# Altium schematic sheet ip2368_out_board.SchDoc
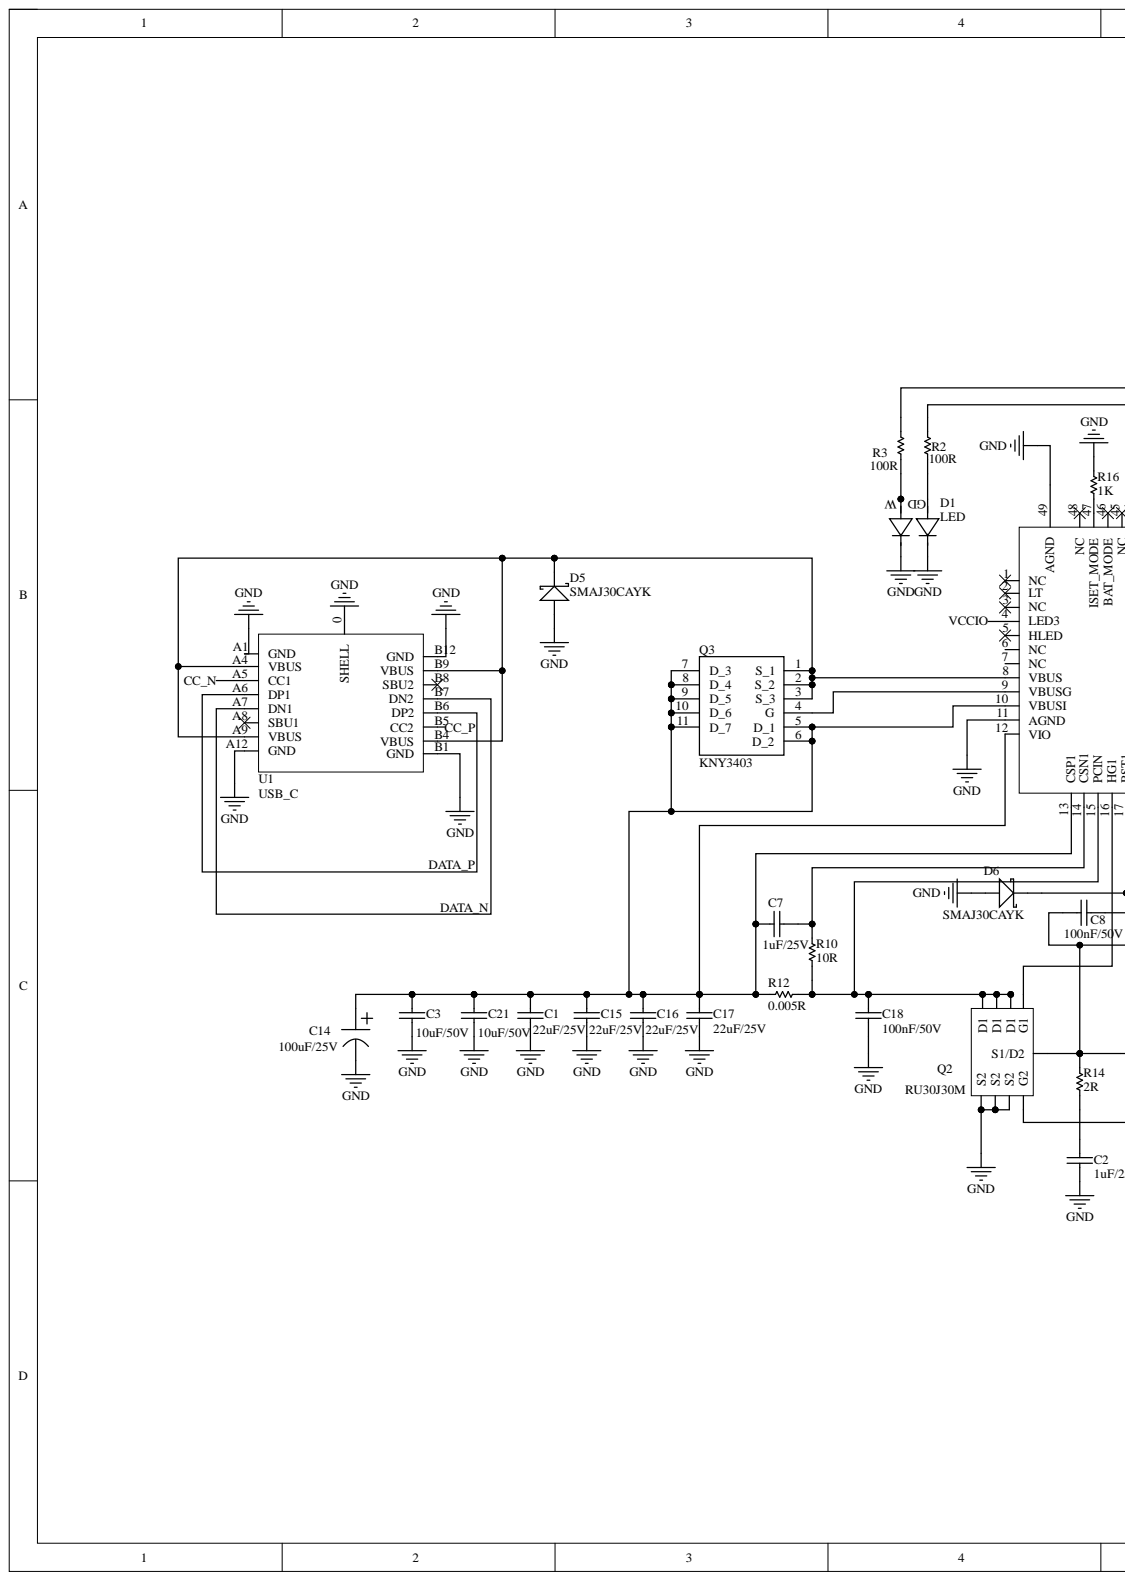
[diagram p0: ip2368_out_board.SchDoc, left]
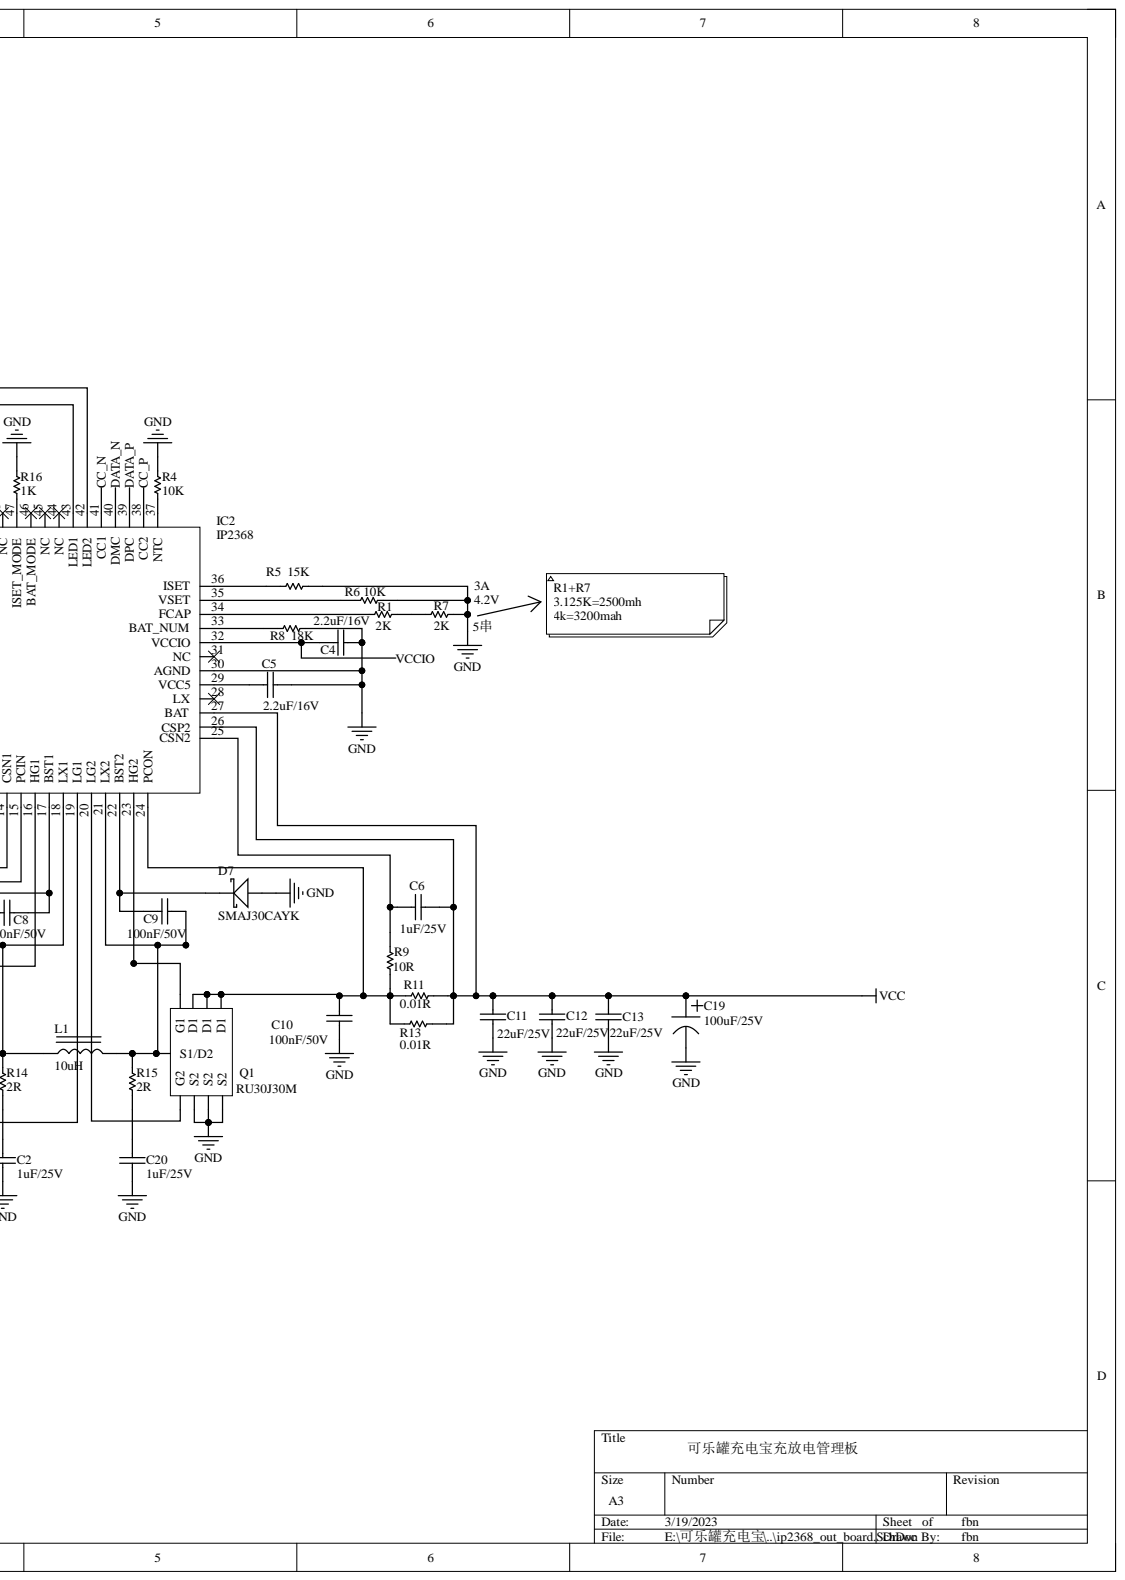
[diagram p1: ip2368_out_board.SchDoc, right]

## Parts
C1  22uF/25V  [1206C]
C2  1uF/25V  [0402C]
C3  10uF/50V  [0805C]
C4  2.2uF/16V  [0402C]
C5  2.2uF/16V  [0402C]
C6  1uF/25V  [0402C]
C7  1uF/25V  [0402C]
C8  100nF/50V  [0402C]
C9  100nF/50V  [0402C]
C10  100nF/50V  [0402C]
C11  22uF/25V  [1206C]
C12  22uF/25V  [1206C]
C13  22uF/25V  [1206C]
C14  100uF/25V  [SMD_2x5x8]
C15  22uF/25V  [1206C]
C16  22uF/25V  [1206C]
C17  22uF/25V  [1206C]
C18  100nF/50V  [0402C]
C19  100uF/25V  [SMD_2x5x8]
C20  1uF/25V  [0402C]
C21  10uF/50V  [0805C]
D1  LED  [SMD2835]
D5  SMAJ30CAYK  [SOD-123]
D6  SMAJ30CAYK  [SOD-123]
D7  SMAJ30CAYK  [SOD-123]
IC2  IP2368  [QFN50P700X700X90-49N-D]
L1  10uH  [SMD_12x12x6]
Q1  RU30J30M  [PDFN5x6]
Q2  RU30J30M  [PDFN5x6]
Q3  KNY3403  [DFN5x6]
R1  2K  [0402R]
R2  100R  [0402R]
R3  100R  [0402R]
R4  10K  [0402R]
R5  15K  [0402R]
R6  10K  [0402R]
R7  2K  [0402R]
R8  18K  [0402R]
R9  10R  [0402R]
R10  10R  [0402R]
R11  0.01R  [1206R]
R12  0.005R  [2512R]
R13  0.01R  [1206R]
R14  2R  [0402R]
R15  2R  [0402R]
R16  1K  [0402R]
U1  USB_C  [USB-C 立贴]

## Nets
GND: C1.1 C2.2 C3.1 C4.1 C5.1 C10.1 C11.1 C12.1 C13.1 C14.1 C15.1 C16.1 C17.1 C18.1 C19.1 C20.2 C21.1 D1.1 D1.3 D5.A D6.A D7.A IC2.11(AGND) IC2.30(AGND) IC2.49(AGND) Q1.5(S2) Q1.6(S2) Q1.7(S2) Q2.5(S2) Q2.6(S2) Q2.7(S2) R4.2 R5.2 R6.2 R7.2 R8.2 R16.2 U1.0(SHELL) U1.A1(GND) U1.A12(GND) U1.B1(GND) U1.B12(GND)
VCC: C6.1 C11.2 C12.2 C13.2 C19.0 IC2.26(CSP2) IC2.27(BAT) R11.2 R13.2
CC_N: IC2.41(CC1) U1.A5(CC1)
CC_P: IC2.38(CC2) U1.B5(CC2)
DATA_N: IC2.40(DMC) U1.A7(DN1) U1.B7(DN2)
DATA_P: IC2.39(DPC) U1.A6(DP1) U1.B6(DP2)
VCCIO: C4.2 IC2.4(LED3) IC2.32(VCCIO)
NetC1_2: C1.2 C3.2 C7.2 C14.0 C15.2 C16.2 C17.2 C21.2 IC2.10(VBUSI) IC2.12(VIO) IC2.13(CSP1) Q3.5(D_1) Q3.6(D_2) Q3.7(D_3) Q3.8(D_4) Q3.9(D_5) Q3.10(D_6) Q3.11(D_7) R12.1
NetC2_1: C2.1 R14.1
NetC5_2: C5.2 IC2.29(VCC5)
NetC6_2: C6.2 IC2.25(CSN2) R9.2
NetC7_1: C7.1 IC2.14(CSN1) R10.2
NetC8_1: C8.1 D6.K IC2.17(BST1)
NetC8_2: C8.2 IC2.18(LX1) L1.0 Q2.9(S1/D2) R14.2
NetC9_1: C9.1 IC2.21(LX2) L1.1 Q1.9(S1/D2) R15.2
NetC9_2: C9.2 D7.K IC2.22(BST2)
NetC10_2: C10.2 IC2.24(PCON) Q1.2(D1) Q1.3(D1) Q1.4(D1) R9.1 R11.1 R13.1
NetC18_2: C18.2 IC2.15(PCIN) Q2.2(D1) Q2.3(D1) Q2.4(D1) R10.1 R12.2
NetC20_1: C20.1 R15.1
NetD1_0: D1.0 R2.1
NetD1_2: D1.2 R3.1
NetD5_K: D5.K IC2.8(VBUS) Q3.1(S_1) Q3.2(S_2) Q3.3(S_3) U1.A4(VBUS) U1.A9(VBUS) U1.B4(VBUS) U1.B9(VBUS)
NetIC2_9: IC2.9(VBUSG) Q3.4(G)
NetIC2_16: IC2.16(HG1) Q2.1(G1)
NetIC2_19: IC2.19(LG1) Q2.8(G2)
NetIC2_20: IC2.20(LG2) Q1.8(G2)
NetIC2_23: IC2.23(HG2) Q1.1(G1)
NetIC2_33: IC2.33(BAT_NUM) R8.1
NetIC2_34: IC2.34(FCAP) R1.1
NetIC2_35: IC2.35(VSET) R6.1
NetIC2_36: IC2.36(ISET) R5.1
NetIC2_37: IC2.37(NTC) R4.1
NetIC2_42: IC2.42(LED2) R3.2
NetIC2_43: IC2.43(LED1) R2.2
NetIC2_47: IC2.47(ISET_MODE) R16.1
NetR1_2: R1.2 R7.1

Not connected: IC2 1(NC) 2(LT) 3(NC) 5(HLED) 6(NC) 7(NC) 28(LX) 31(NC) 44(NC) 45(NC) 46(BAT_MODE) 48(NC); U1 A8(SBU1) B8(SBU2)

## Library data of the parts
C1-C13 C15-C18 C20 C21 = CAP: 无极性电容; Component Kind: Standard; Library Name: 1-电容.IntLib
C14 C19 = 固态电解电容: Component Kind: Standard; Library Name: SamacSys.SchLib
D5-D7 = DIODE SCHOTTKY: 肖特基二极管; Component Kind: Standard; Library Name: 15-二极管.IntLib
L1 = 电感: Component Kind: Standard; Library Name: SamacSys.SchLib
Q3 = CMS70N04H8-HF: MOSFET (N-Channel); Manufacturer_Name: Comchip Technology; Component Kind: Standard; Datasheet Link: https://www.comchiptech.com/admin/files/product/12034_20190327120058.PDF; Height: 1.25mm; Library Name: SamacSys.SchLib; Manufacturer_Part_Number: CMS70N04H8-HF; Mouser Part Number: 750-CMS70N04H8-HF; Mouser Price/Stock: https://www.mouser.co.uk/ProductDetail/Comchip-Technology/CMS70N04H8-HF?qs=Zz7%252BYVVL6bH0Kh4xkHf10Q%3D%3D
R1-R16 = RES: Component Kind: Standard; Library Name: 9-电阻.IntLib
U1 = USB-C  立贴: Component Kind: Standard; Library Name: SamacSys.SchLib
D1 = LED: Component Kind: Standard; Library Name: SamacSys.SchLib
IC2 = IP2368: Component Kind: Standard; Library Name: SamacSys.SchLib
Q1 Q2 = RU30J30M: Component Kind: Standard; Library Name: SamacSys.SchLib
